# Altium schematic sheet RS232 Schematic.SchDoc("RS232 Schematic")
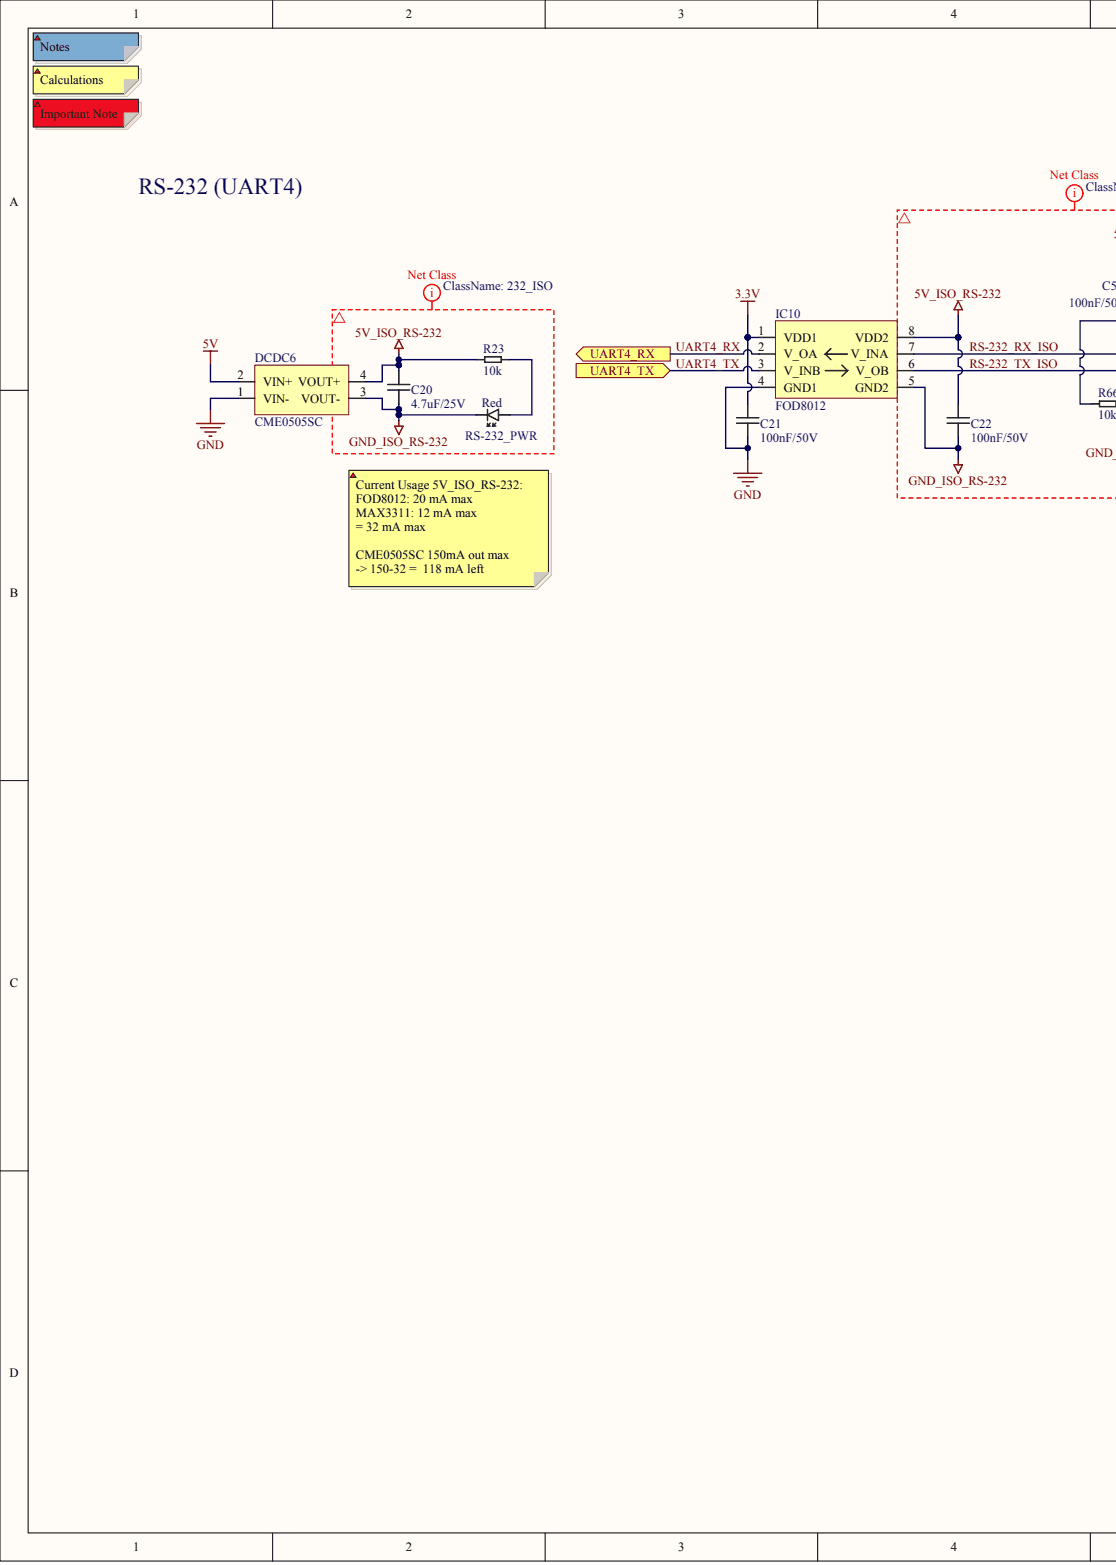
[diagram p0: RS232 Schematic.SchDoc, left]
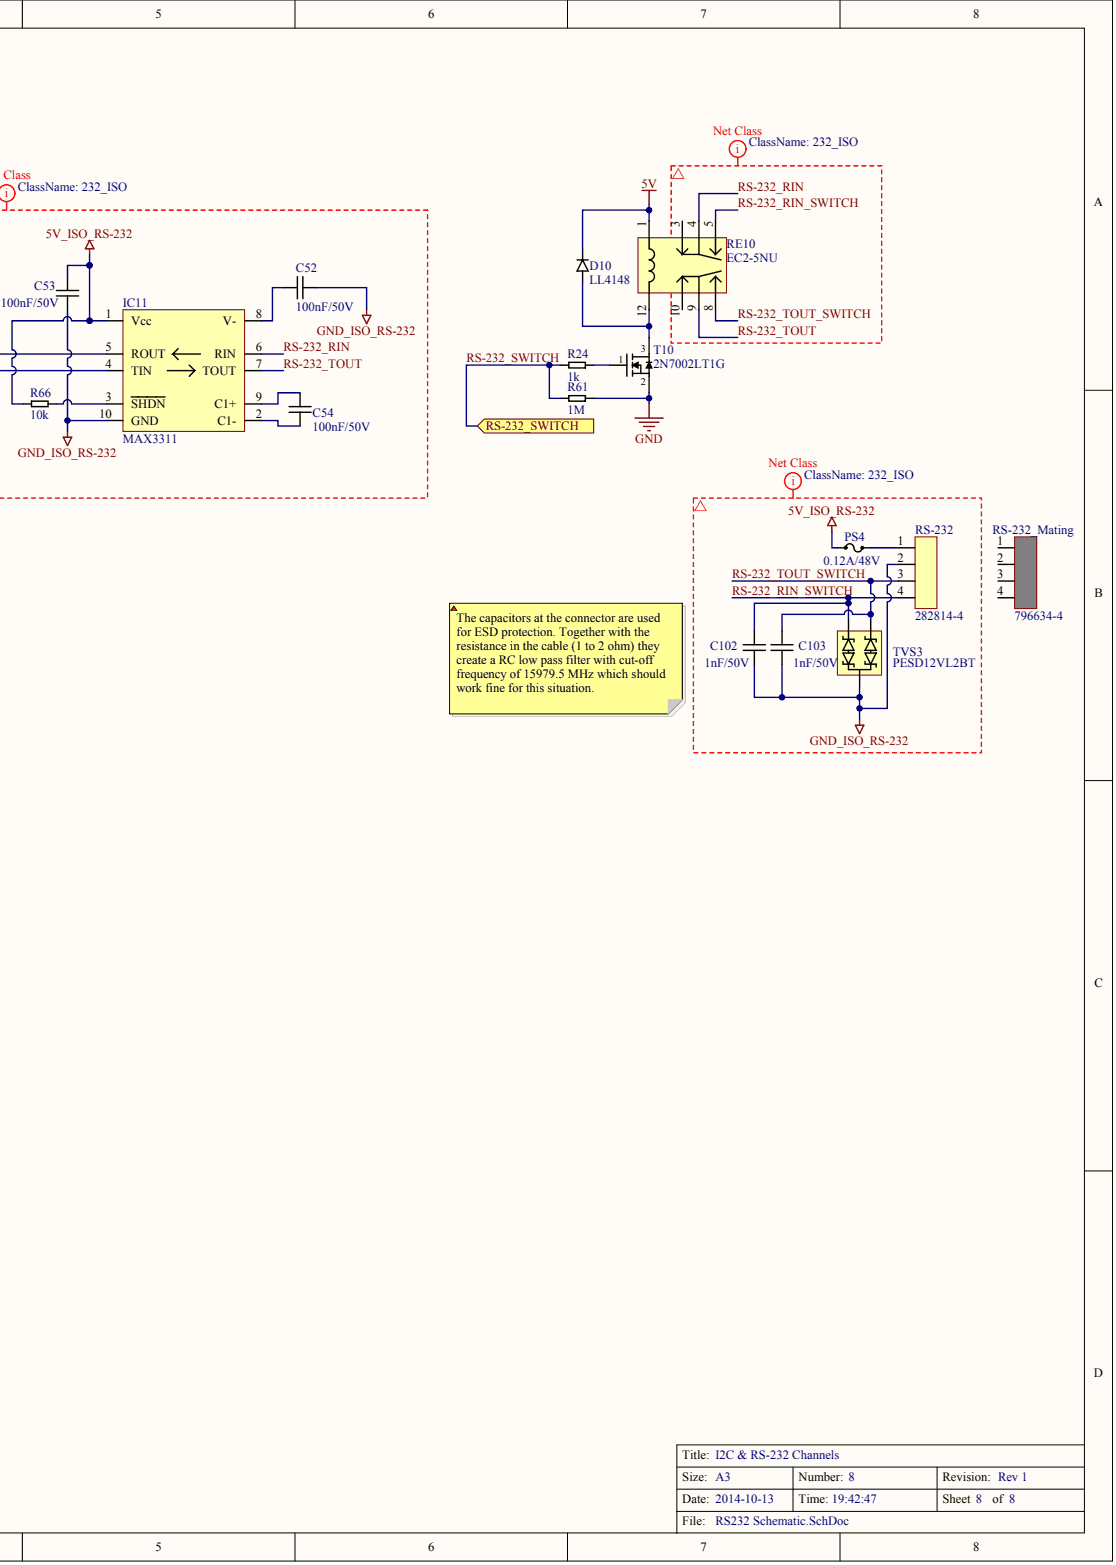
[diagram p1: RS232 Schematic.SchDoc, right]

## Parts
C20  value 4.7uF/25V  [0805]  (hidden comment: Cap_0805_4.7uF/25V)
C21  value 100nF/50V  [0603]  (hidden comment: Cap_0603_100nF/50V)
C22  value 100nF/50V  [0603]  (hidden comment: Cap_0603_100nF/50V)
C52  value 100nF/50V  [0603]  (hidden comment: Cap_0603_100nF/50V)
C53  value 100nF/50V  [0603]  (hidden comment: Cap_0603_100nF/50V)
C54  value 100nF/50V  [0603]  (hidden comment: Cap_0603_100nF/50V)
C102  value 1nF/50V  [0603]  (hidden comment: Cap_0603_1nF/50V)
C103  value 1nF/50V  [0603]  (hidden comment: Cap_0603_1nF/50V)
D10  LL4148
DCDC6  CME0505SC  [4-SIP]
IC10  FOD8012
IC11  MAX3311
PS4  value 0.12A/48V  [SMD 1206]  (hidden comment: Polyswitch_1206_0.12Ahold_48V)
R23  value 10k  [0603]  (hidden comment: Res_0603_10k)
R24  value 1k  [0603]  (hidden comment: Res_0603_1k)
R61  value 1M  [0603]  (hidden comment: Res_0603_1M)
R66  value 10k  [0603]  (hidden comment: Res_0603_10k)
RE10  value EC2-5NU  Part No.: EC2-5NU  (hidden comment: Relay EC2-5NU)
RS-232  value 282814-4  Part No.: 282814-4  (hidden comment: Terminal Block 1x4 5.08mm)
RS-232_Mating  value 796634-4  Part No.: 796634-4  (hidden comment: Terminal Block 1x4 5.08mm Mating Connector)
RS-232_PWR  value Red  (hidden comment: LED_0603_Red)
T10  2N7002LT1G  [SOT-23]
TVS3  PESD12VL2BT

## Ports
RS-232_SWITCH, UART4_RX, UART4_TX

## Nets
3.3V: C21.2 IC10.1(VDD1)
5V: D10.1 DCDC6.2(VIN+) RE10.1
5V_ISO_RS-232: C20.2 C22.2 C53.2 DCDC6.4(VOUT+) IC10.8(VDD2) IC11.1(Vcc) PS4.1 R23.2 R66.2
GND: C21.1 DCDC6.1(VIN-) IC10.4(GND1) R61.2 T10.2
GND_ISO_RS-232: C20.1 C22.1 C52.1 C53.1 C102.1 C103.1 DCDC6.3(VOUT-) IC10.5(GND2) IC11.10(GND) RS-232.2 RS-232_PWR.CATHODE TVS3.3
RS-232_RIN: IC11.6(RIN) RE10.4
RS-232_RIN_SWITCH: C102.2 RE10.5 RS-232.4 TVS3.2
RS-232_RX_ISO: IC10.7(V_INA) IC11.5(ROUT)
RS-232_SWITCH: R24.1 R61.1 ; port RS-232_SWITCH
RS-232_TOUT: IC11.7(TOUT) RE10.9
RS-232_TOUT_SWITCH: C103.2 RE10.8 RS-232.3 TVS3.1
RS-232_TX_ISO: IC10.6(V_OB) IC11.4(TIN)
UART4_RX: IC10.2(V_OA) ; port UART4_RX
UART4_TX: IC10.3(V_INB) ; port UART4_TX
NetC52_2: C52.2 IC11.8(V-)
NetC54_1: C54.1 IC11.2(C1-)
NetC54_2: C54.2 IC11.9(C1+)
NetD10_2: D10.2 RE10.12 T10.3
NetIC11_3: IC11.3(SHDN) R66.1
NetPS4_2: PS4.2 RS-232.1
NetR23_1: R23.1 RS-232_PWR.ANODE
NetR24_2: R24.2 T10.1
N00186:
N00187:
N00188:
N00189:

Not connected: RE10 3 10; RS-232_Mating 1 2 3 4

## Library data of the parts
D10 = (no symbol name): Manufacturer: Fairchild Semiconductor; Mouser: 512-LL4148; DigiKey: LL4148FSCT-ND; Value: LL4148; Price SEK (No VAT): 1; Part No.: LL4148
DCDC6 = (no symbol name): Manufacturer: Murata; DigiKey: 811-2894-5-ND; Part No.: CME0505SC; Mouser: 580-CME0505SC; Datasheet: http://www.murata-ps.com/data/power/ncl/kdc_cme.pdf; Price SEK (No VAT): 28
IC10 = (no symbol name): Manufacturer: Fairchild Semiconductor; Note: Should be able to replace ADUM1201 as pin-compatible; DigiKey: FOD8012-ND; Part No.: FOD8012; Price SEK (No VAT): 31; Datasheet: http://www.fairchildsemi.com/ds/FO/FOD8012.pdf; Mouser: 512-FOD8012; Value: FOD8012
IC11 = (no symbol name): Part No.: MAX3311CUB+; Manufacturer: Maxim; DigiKey: MAX3311CUB+-ND; Datasheet: http://datasheets.maximintegrated.com/en/ds/MAX3311-MAX3313.pdf; Mouser: 700-MAX3311CUB; Price SEK (No VAT): 14
PS4 = (no symbol name): Part No.: NANOSMDC012F-2; Manufacturer: TE Connectivity; RS: 782-6455; Mouser: 650-NANOSMDC012F-2; Price SEK (No VAT): 2; DigiKey: NANOSMDC012FCT-ND; Farnell: 1345937
RE10 = (no symbol name): Manufacturer: Kemet; Mouser: 80-EC2-5NU; DigiKey: 399-11052-5-ND; Price SEK (No VAT): 12; Datasheet: http://www.kemet.com/Lists/ProductCatalog/Attachments/527/KEM_R7002_EC2_EE2.pdf
RS-232 = (no symbol name): Manufacturer: TE Connectivity; Mating Connector: 796634-4; Mouser: 571-282814-4; RS: *; DigiKey: A98067-ND; Elfa: *; Price SEK (No VAT): 8
RS-232_Mating = (no symbol name): Manufacturer: TE Connectivity; Mouser: 571-7966344; RS: *; DigiKey: A98225-ND; Elfa: *; Price SEK (No VAT): 18
T10 = (no symbol name): Manufacturer: ON Semiconductor; Mouser: 863-2N7002LT1G; Part No.: 2N7002LT1G; DigiKey: 2N7002LT1GOSCT-ND; Price SEK (No VAT): 2; Value: 2N7002LT1G
TVS3 = (no symbol name): Manufacturer: NXP; Part No.: PESD12VL2BT,215; Mouser: 771-PESD12VL2BT-T/R; DigiKey: 568-4023-1-ND; Price SEK (No VAT): 4; Value: PESD12VL2BT
C20-C22 C52-C54 C102 C103 R23 R24 R61 R66 RS-232_PWR = (no symbol name)
  R23 R24 R61 R66: Price (No VAT): 0,1
